# Altium schematic sheet HoverGate-V2-sch.SchDoc
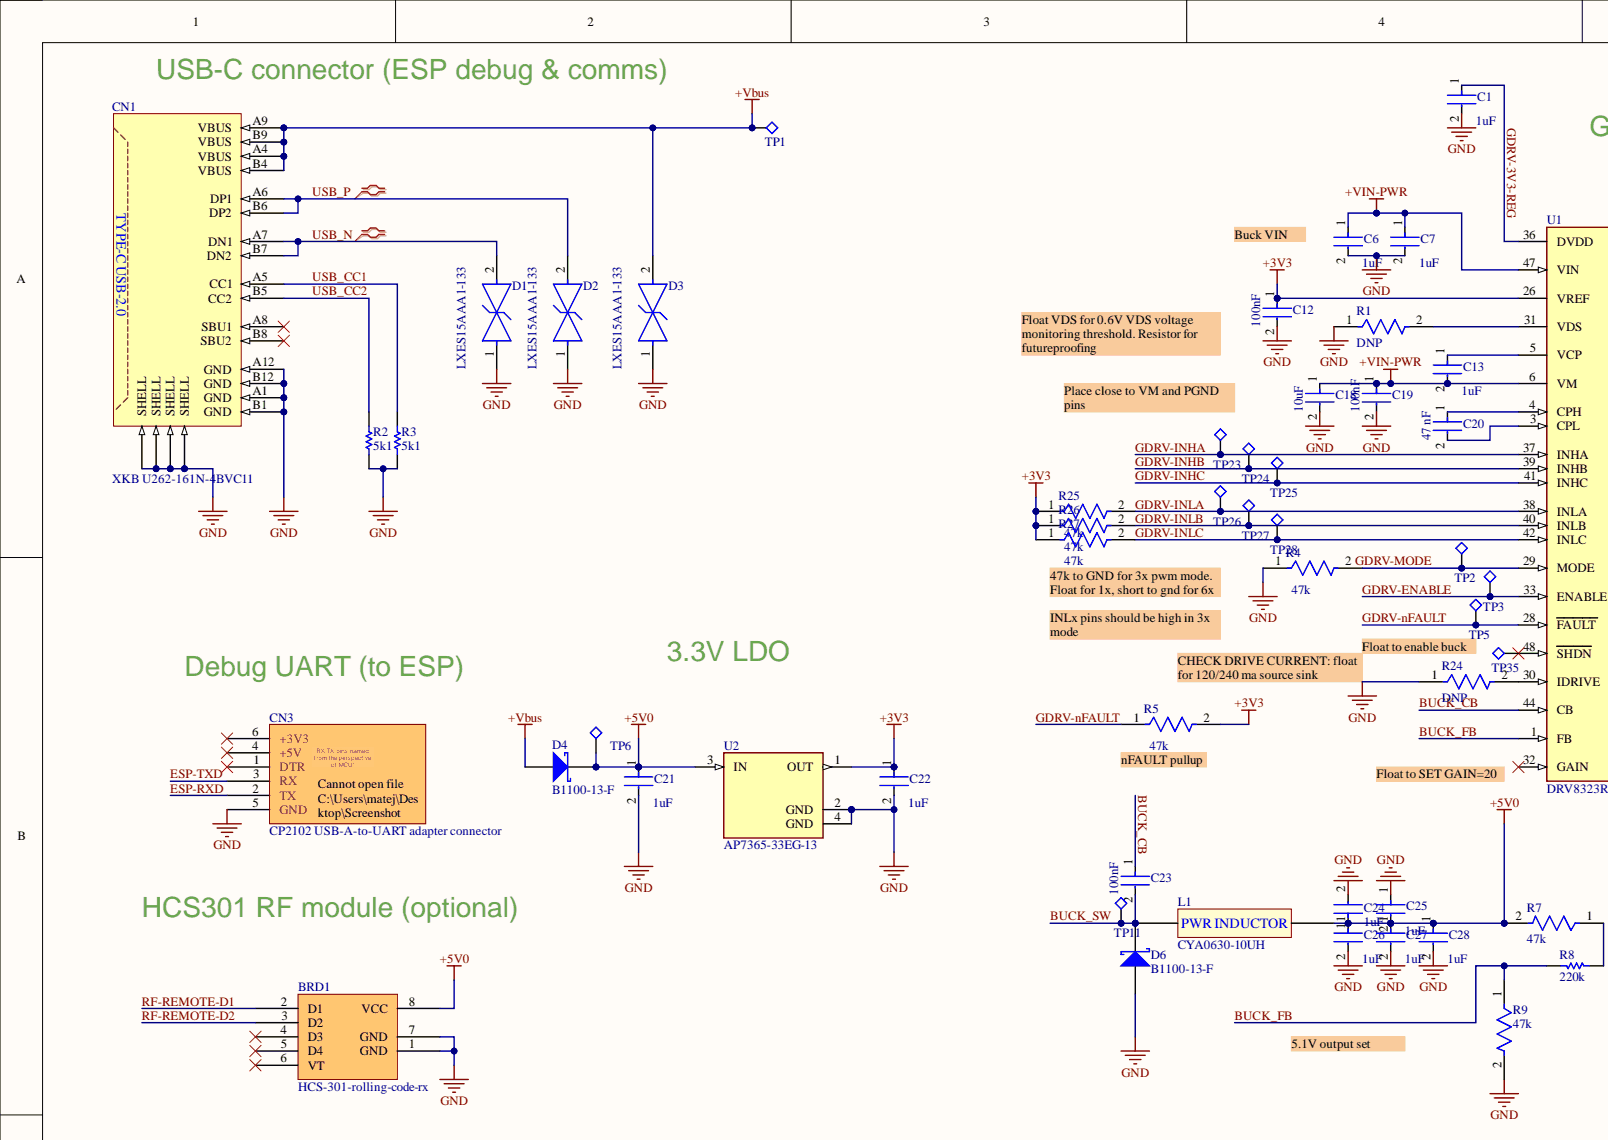
[diagram p0: HoverGate-V2-sch.SchDoc, top left]
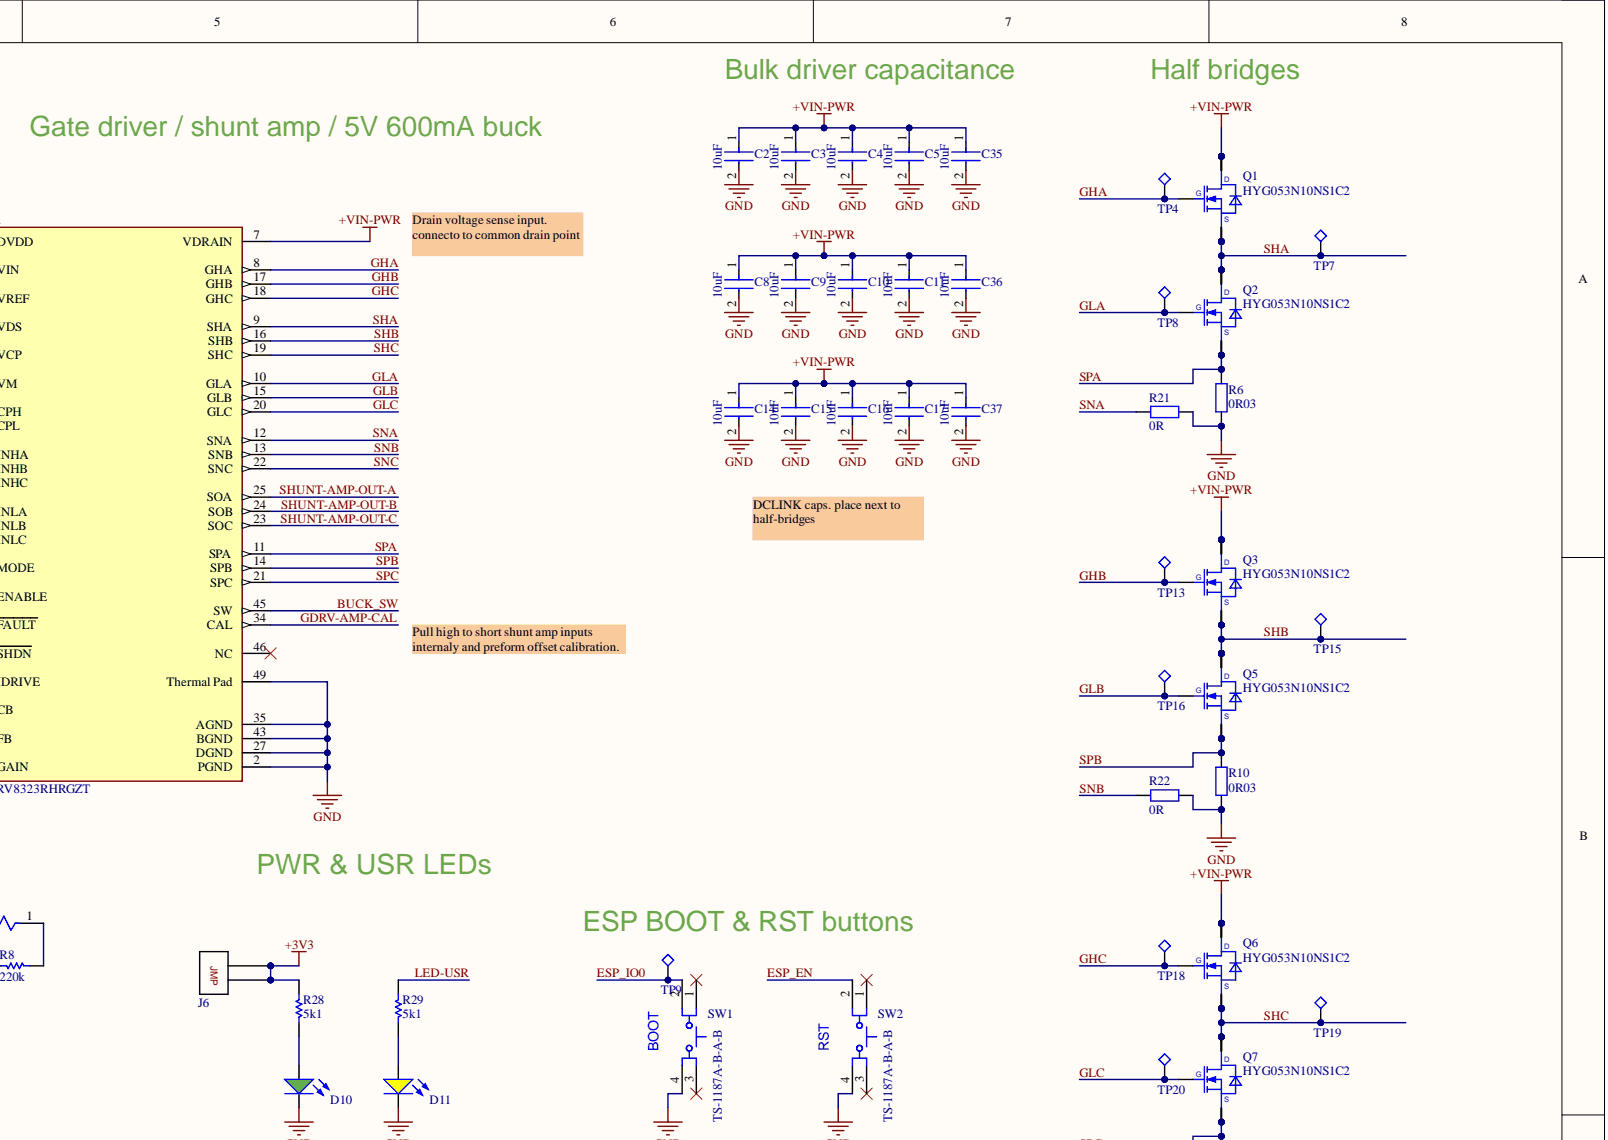
[diagram p1: HoverGate-V2-sch.SchDoc, top right]
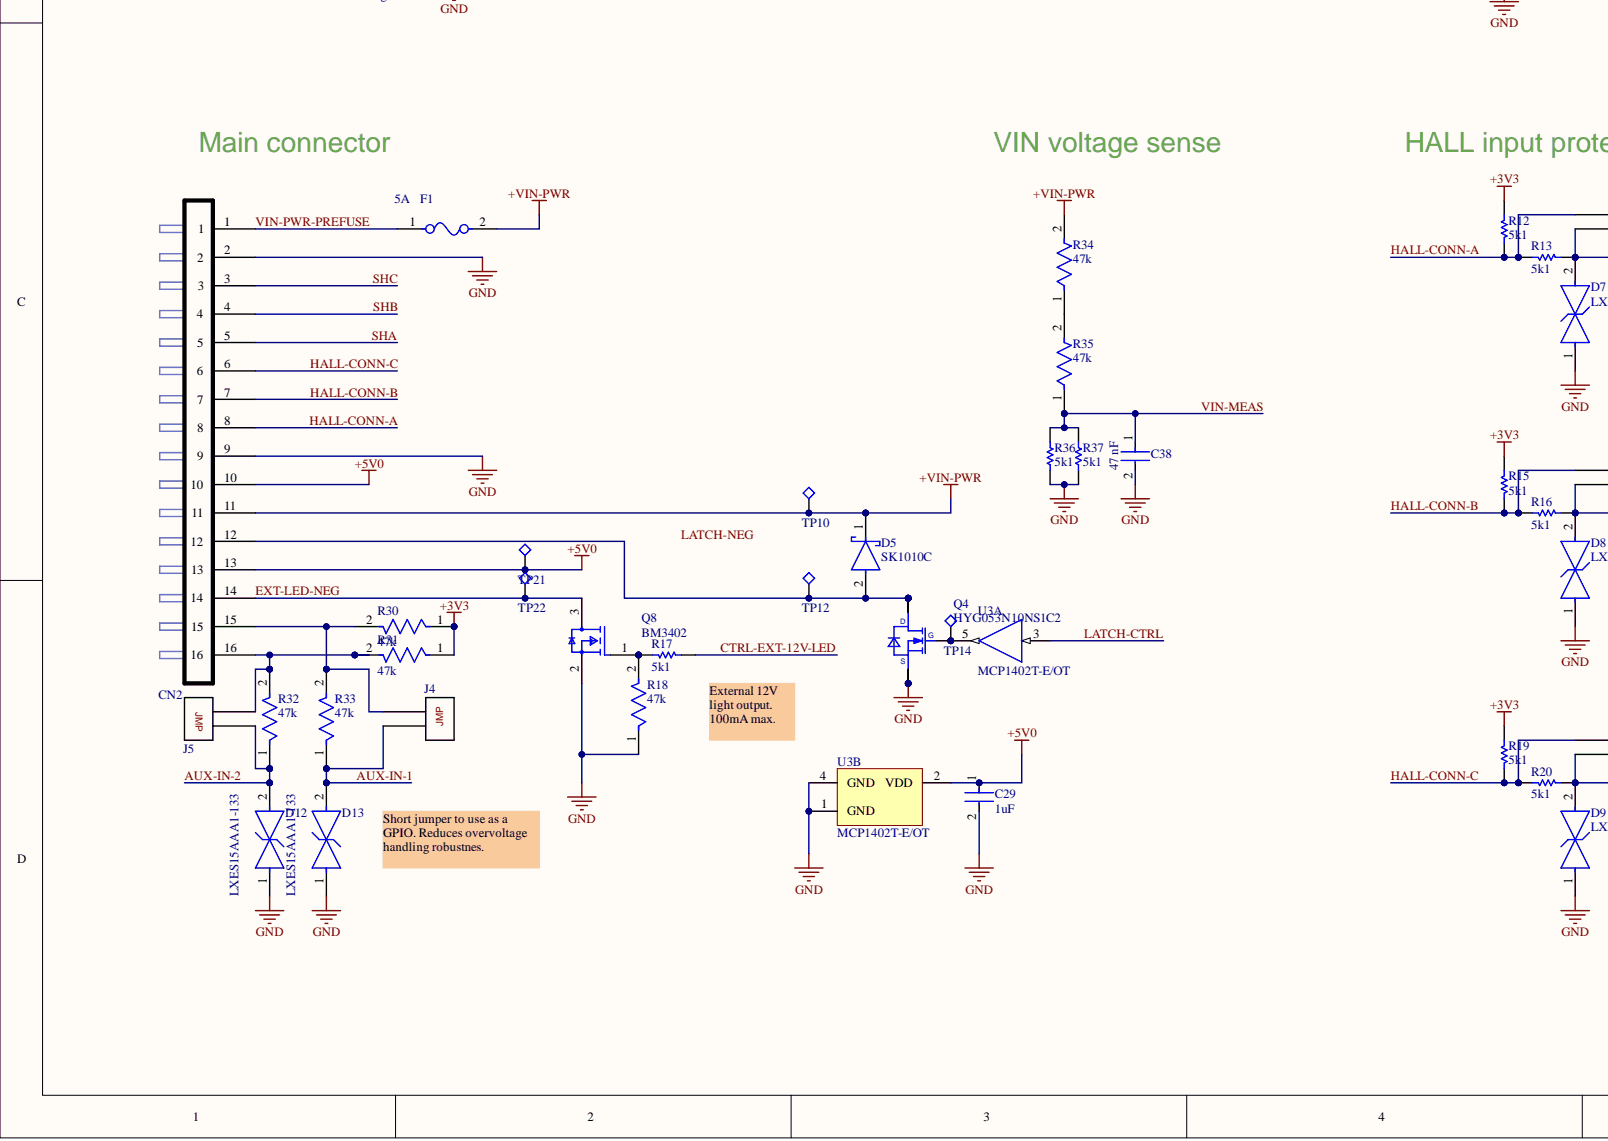
[diagram p2: HoverGate-V2-sch.SchDoc, bottom left]
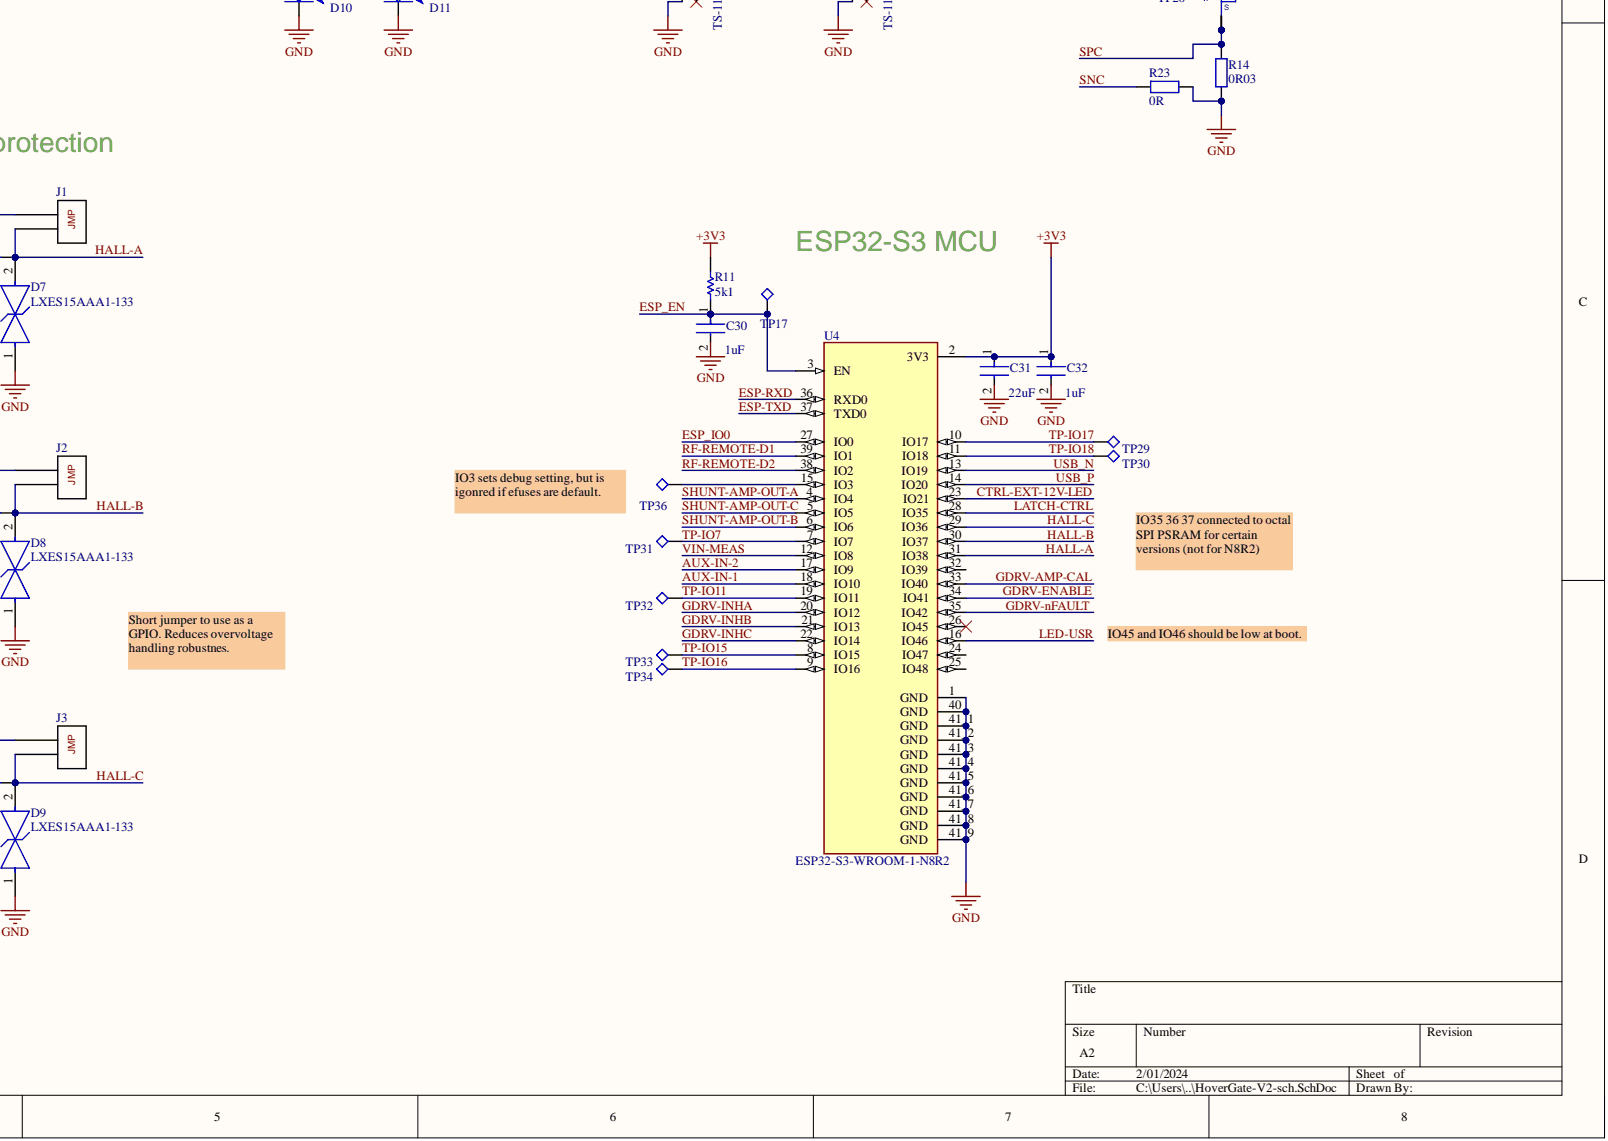
[diagram p3: HoverGate-V2-sch.SchDoc, bottom right]

## Parts
BRD1  HCS-301-rolling-code-rx  [HC301-module]
C1  value 1uF  Capacitance: 1uF  [FP-CL10B105KO8NNNC-IPC_B]  (hidden comment: CL10A105KB8NNNC)
C2  Capacitance: 10uF  [FP-GRM32E-0_2-0_3-MFG]  (hidden comment: GRM32ER71J106KA12L)
C3  Capacitance: 10uF  [FP-GRM32E-0_2-0_3-MFG]  (hidden comment: GRM32ER71J106KA12L)
C4  Capacitance: 10uF  [FP-GRM32E-0_2-0_3-MFG]  (hidden comment: GRM32ER71J106KA12L)
C5  Capacitance: 10uF  [FP-GRM32E-0_2-0_3-MFG]  (hidden comment: GRM32ER71J106KA12L)
C6  value 1uF  Capacitance: 1uF  [FP-CL10B105KO8NNNC-IPC_B]  (hidden comment: CL10A105KB8NNNC)
C7  value 1uF  Capacitance: 1uF  [FP-CL10B105KO8NNNC-IPC_B]  (hidden comment: CL10A105KB8NNNC)
C8  Capacitance: 10uF  [FP-GRM32E-0_2-0_3-MFG]  (hidden comment: GRM32ER71J106KA12L)
C9  Capacitance: 10uF  [FP-GRM32E-0_2-0_3-MFG]  (hidden comment: GRM32ER71J106KA12L)
C10  Capacitance: 10uF  [FP-GRM32E-0_2-0_3-MFG]  (hidden comment: GRM32ER71J106KA12L)
C11  Capacitance: 10uF  [FP-GRM32E-0_2-0_3-MFG]  (hidden comment: GRM32ER71J106KA12L)
C12  Capacitance: 100nF  [FP-CL10-IPC_A]  (hidden comment: CL10B104KC8NNNC)
C13  value 1uF  Capacitance: 1uF  [FP-CL10B105KO8NNNC-IPC_B]  (hidden comment: CL10A105KB8NNNC)
C14  Capacitance: 10uF  [FP-GRM32E-0_2-0_3-MFG]  (hidden comment: GRM32ER71J106KA12L)
C15  Capacitance: 10uF  [FP-GRM32E-0_2-0_3-MFG]  (hidden comment: GRM32ER71J106KA12L)
C16  Capacitance: 10uF  [FP-GRM32E-0_2-0_3-MFG]  (hidden comment: GRM32ER71J106KA12L)
C17  Capacitance: 10uF  [FP-GRM32E-0_2-0_3-MFG]  (hidden comment: GRM32ER71J106KA12L)
C18  Capacitance: 10uF  [FP-GRM32E-0_2-0_3-MFG]  (hidden comment: GRM32ER71J106KA12L)
C19  Capacitance: 100nF  [FP-CL10-IPC_A]  (hidden comment: CL10B104KC8NNNC)
C20  value 47 nF  Capacitance: 47 nF  [FP-AC0603-IPC_B]  (hidden comment: AC0603KRX7R0BB473)
C21  value 1uF  Capacitance: 1uF  [FP-CL10B105KO8NNNC-IPC_B]  (hidden comment: CL10A105KB8NNNC)
C22  value 1uF  Capacitance: 1uF  [FP-CL10B105KO8NNNC-IPC_B]  (hidden comment: CL10A105KB8NNNC)
C23  Capacitance: 100nF  [FP-CL10-IPC_A]  (hidden comment: CL10B104KC8NNNC)
C24  value 1uF  Capacitance: 1uF  [FP-CL10B105KO8NNNC-IPC_B]  (hidden comment: CL10A105KB8NNNC)
C25  value 1uF  Capacitance: 1uF  [FP-CL10B105KO8NNNC-IPC_B]  (hidden comment: CL10A105KB8NNNC)
C26  value 1uF  Capacitance: 1uF  [FP-CL10B105KO8NNNC-IPC_B]  (hidden comment: CL10A105KB8NNNC)
C27  value 1uF  Capacitance: 1uF  [FP-CL10B105KO8NNNC-IPC_B]  (hidden comment: CL10A105KB8NNNC)
C28  value 1uF  Capacitance: 1uF  [FP-CL10B105KO8NNNC-IPC_B]  (hidden comment: CL10A105KB8NNNC)
C29  value 1uF  Capacitance: 1uF  [FP-CL10B105KO8NNNC-IPC_B]  (hidden comment: CL10A105KB8NNNC)
C30  value 1uF  Capacitance: 1uF  [FP-CL10B105KO8NNNC-IPC_B]  (hidden comment: CL10A105KB8NNNC)
C31  Capacitance: 22uF  [FP-CL31-IPC_B]  (hidden comment: CL31A226KPHNNNE)
C32  value 1uF  Capacitance: 1uF  [FP-CL10B105KO8NNNC-IPC_B]  (hidden comment: CL10A105KB8NNNC)
C35  Capacitance: 10uF  [FP-GRM32E-0_2-0_3-MFG]  (hidden comment: GRM32ER71J106KA12L)
C36  Capacitance: 10uF  [FP-GRM32E-0_2-0_3-MFG]  (hidden comment: GRM32ER71J106KA12L)
C37  Capacitance: 10uF  [FP-GRM32E-0_2-0_3-MFG]  (hidden comment: GRM32ER71J106KA12L)
C38  value 47 nF  Capacitance: 47 nF  [FP-AC0603-IPC_B]  (hidden comment: AC0603KRX7R0BB473)
CN1  XKB U262-161N-4BVC11  [XKB U262-161N-4BVC11]
CN2  MAX MX15EDGVM-3.81-16P-GN01-Cu-A  [MAX MX15EDGVM-3.81-16P-GN01-Cu-A]  (value not drawn)
CN3  CP2102 USB-A-to-UART adapter connector  [CP2102 USB-A-to-UART adapter connector]
D1  LXES15AAA1-133  [FP-LXES15-IPC_A]
D2  LXES15AAA1-133  [FP-LXES15-IPC_A]
D3  LXES15AAA1-133  [FP-LXES15-IPC_A]
D4  B1100-13-F  [DIOD-SMA-2_V]
D5  SK1010C  [FP-SMC-MFG]
D6  B1100-13-F  [DIOD-SMA-2_V]
D7  LXES15AAA1-133  [FP-LXES15-IPC_A]
D8  LXES15AAA1-133  [FP-LXES15-IPC_A]
D9  LXES15AAA1-133  [FP-LXES15-IPC_A]
D10  KT-0805G  [LED_0805]  (value not drawn)
D11  KT-0805Y  [LED_0805]  (value not drawn)
D12  LXES15AAA1-133  [FP-LXES15-IPC_A]
D13  LXES15AAA1-133  [FP-LXES15-IPC_A]
F1  Current Rating: 5A  [FP-0469-IPC_B]  (hidden comment: 0458005.DR)
J1  SolderJumperV2  [AislerRule_SolderJumper_1mm]  (value not drawn)
J2  SolderJumperV2  [AislerRule_SolderJumper_1mm]  (value not drawn)
J3  SolderJumperV2  [AislerRule_SolderJumper_1mm]  (value not drawn)
J4  SolderJumperV2  [AislerRule_SolderJumper_1mm]  (value not drawn)
J5  SolderJumperV2  [AislerRule_SolderJumper_1mm]  (value not drawn)
J6  SolderJumperV2  [AislerRule_SolderJumper_1mm]  (value not drawn)
L1  CYA0630-10UH  [CYA0630-10UH]
Q1  HYG053N10NS1C2  [PDFN5*6-8L]
Q2  HYG053N10NS1C2  [PDFN5*6-8L]
Q3  HYG053N10NS1C2  [PDFN5*6-8L]
Q4  HYG053N10NS1C2  [PDFN5*6-8L]
Q5  HYG053N10NS1C2  [PDFN5*6-8L]
Q6  HYG053N10NS1C2  [PDFN5*6-8L]
Q7  HYG053N10NS1C2  [PDFN5*6-8L]
Q8  BM3402  [FP-SOT23-IPC_B]
R1  DNP  [FP-RC0603FR-0747KL-MFG]
R2  value 5k1  [RESC1608X55X30NL15T15]  (hidden comment: 0603WAF5101T5E)
R3  value 5k1  [RESC1608X55X30NL15T15]  (hidden comment: 0603WAF5101T5E)
R4  Resistance: 47k  [FP-RC0603FR-0747KL-MFG]  (hidden comment: RC0603FR-0747KL)
R5  Resistance: 47k  [FP-RC0603FR-0747KL-MFG]  (hidden comment: RC0603FR-0747KL)
R6  value 0R03  [FPR_CRF2512_R0]  (hidden comment: LR2512D-3W-3mR-1%)
R7  Resistance: 47k  [FP-RC0603FR-0747KL-MFG]  (hidden comment: RC0603FR-0747KL)
R8  value 220k  [RESC1608X55X30NL15T15]  (hidden comment: 0603WAF2203T5E)
R9  Resistance: 47k  [FP-RC0603FR-0747KL-MFG]  (hidden comment: RC0603FR-0747KL)
R10  value 0R03  [FPR_CRF2512_R0]  (hidden comment: LR2512D-3W-3mR-1%)
R11  value 5k1  [RESC1608X55X30NL15T15]  (hidden comment: 0603WAF5101T5E)
R12  value 5k1  [RESC1608X55X30NL15T15]  (hidden comment: 0603WAF5101T5E)
R13  value 5k1  [RESC1608X55X30NL15T15]  (hidden comment: 0603WAF5101T5E)
R14  value 0R03  [FPR_CRF2512_R0]  (hidden comment: LR2512D-3W-3mR-1%)
R15  value 5k1  [RESC1608X55X30NL15T15]  (hidden comment: 0603WAF5101T5E)
R16  value 5k1  [RESC1608X55X30NL15T15]  (hidden comment: 0603WAF5101T5E)
R17  value 5k1  [RESC1608X55X30NL15T15]  (hidden comment: 0603WAF5101T5E)
R18  Resistance: 47k  [FP-RC0603FR-0747KL-MFG]  (hidden comment: RC0603FR-0747KL)
R19  value 5k1  [RESC1608X55X30NL15T15]  (hidden comment: 0603WAF5101T5E)
R20  value 5k1  [RESC1608X55X30NL15T15]  (hidden comment: 0603WAF5101T5E)
R21  0R  [FPR_0603_R_R0]
R22  0R  [FPR_0603_R_R0]
R23  0R  [FPR_0603_R_R0]
R24  DNP  [FP-RC0603FR-0747KL-MFG]
R25  RC0603FR-0747KL  [FP-RC0603FR-0747KL-MFG]  (value not drawn)
R26  Resistance: 47k  [FP-RC0603FR-0747KL-MFG]  (hidden comment: RC0603FR-0747KL)
R27  Resistance: 47k  [FP-RC0603FR-0747KL-MFG]  (hidden comment: RC0603FR-0747KL)
R28  value 5k1  [RESC1608X55X30NL15T15]  (hidden comment: 0603WAF5101T5E)
R29  value 5k1  [RESC1608X55X30NL15T15]  (hidden comment: 0603WAF5101T5E)
R30  Resistance: 47k  [FP-RC0603FR-0747KL-MFG]  (hidden comment: RC0603FR-0747KL)
R31  Resistance: 47k  [FP-RC0603FR-0747KL-MFG]  (hidden comment: RC0603FR-0747KL)
R32  Resistance: 47k  [FP-RC0603FR-0747KL-MFG]  (hidden comment: RC0603FR-0747KL)
R33  Resistance: 47k  [FP-RC0603FR-0747KL-MFG]  (hidden comment: RC0603FR-0747KL)
R34  Resistance: 47k  [FP-RC0603FR-0747KL-MFG]  (hidden comment: RC0603FR-0747KL)
R35  Resistance: 47k  [FP-RC0603FR-0747KL-MFG]  (hidden comment: RC0603FR-0747KL)
R36  value 5k1  [RESC1608X55X30NL15T15]  (hidden comment: 0603WAF5101T5E)
R37  value 5k1  [RESC1608X55X30NL15T15]  (hidden comment: 0603WAF5101T5E)
SW1  TS-1187A-B-A-B  [ESWI-TL3342F160QG_V]
SW2  TS-1187A-B-A-B  [ESWI-TL3342F160QG_V]
TP1  test point 1.5mm  [TP1_FULL]  (value not drawn)
TP2  test point 1.5mm  [TP1_FULL]  (value not drawn)
TP3  test point 1.5mm  [TP1_FULL]  (value not drawn)
TP4  test point 1.5mm  [TP1_FULL]  (value not drawn)
TP5  test point 1.5mm  [TP1_FULL]  (value not drawn)
TP6  test point 1.5mm  [TP1_FULL]  (value not drawn)
TP7  test point 1.5mm  [TP1_FULL]  (value not drawn)
TP8  test point 1.5mm  [TP1_FULL]  (value not drawn)
TP9  test point 1.5mm  [TP1_FULL]  (value not drawn)
TP10  test point 1.5mm  [TP1_FULL]  (value not drawn)
TP11  test point 1.5mm  [TP1_FULL]  (value not drawn)
TP12  test point 1.5mm  [TP1_FULL]  (value not drawn)
TP13  test point 1.5mm  [TP1_FULL]  (value not drawn)
TP14  test point 1.5mm  [TP1_FULL]  (value not drawn)
TP15  test point 1.5mm  [TP1_FULL]  (value not drawn)
TP16  test point 1.5mm  [TP1_FULL]  (value not drawn)
TP17  test point 1.5mm  [TP1_FULL]  (value not drawn)
TP18  test point 1.5mm  [TP1_FULL]  (value not drawn)
TP19  test point 1.5mm  [TP1_FULL]  (value not drawn)
TP20  test point 1.5mm  [TP1_FULL]  (value not drawn)
TP21  test point 1.5mm  [TP1_FULL]  (value not drawn)
TP22  test point 1.5mm  [TP1_FULL]  (value not drawn)
TP23  test point 1.5mm  [TP1_FULL]  (value not drawn)
TP24  test point 1.5mm  [TP1_FULL]  (value not drawn)
TP25  test point 1.5mm  [TP1_FULL]  (value not drawn)
TP26  test point 1.5mm  [TP1_FULL]  (value not drawn)
TP27  test point 1.5mm  [TP1_FULL]  (value not drawn)
TP28  test point 1.5mm  [TP1_FULL]  (value not drawn)
TP29  test point 1.5mm  [TP1_FULL]  (value not drawn)
TP30  test point 1.5mm  [TP1_FULL]  (value not drawn)
TP31  test point 1.5mm  [TP1_FULL]  (value not drawn)
TP32  test point 1.5mm  [TP1_FULL]  (value not drawn)
TP33  test point 1.5mm  [TP1_FULL]  (value not drawn)
TP34  test point 1.5mm  [TP1_FULL]  (value not drawn)
TP35  test point 1.5mm  [TP1_FULL]  (value not drawn)
TP36  test point 1.5mm  [TP1_FULL]  (value not drawn)
U1  DRV8323RHRGZT  [FP-RGZ0048A-IPC_A]
U2  AP7365-33EG-13  [FP-SOT223-IPC_C]
U3  MCP1402T-E/OT  [SOT-23-OT5_L]  (parts A,B)
U4  ESP32-S3-WROOM-1-N8R2  [XCVR_ESP32-S3-WROOM-1-N8R2]

## Nets
+3V3: C12.1 C22.1 C31.1 C32.1 J6.1 J6.2 R5.2 R11.2 R12.2 R15.2 R19.2 R25.1 R26.1 R27.1 R28.2 R30.1 R31.1 U1.26(VREF) U2.1(OUT) U4.2(3V3)
+5V0: BRD1.8(VCC) C21.1 C24.1 C25.2 C26.1 C27.1 C28.1 C29.1 CN2.10 CN2.13 D4.2 L1.2 R7.2 TP6.1 TP21.1 U2.3(IN) U3.2(VDD)
+Vbus: CN1.A4(VBUS) CN1.A9(VBUS) CN1.B4(VBUS) CN1.B9(VBUS) D3.2 D4.1 TP1.1
+VIN-PWR: C2.1 C3.1 C4.1 C5.1 C6.1 C7.1 C8.1 C9.1 C10.1 C11.1 C13.2 C14.1 C15.1 C16.1 C17.1 C18.1 C19.1 C35.1 C36.1 C37.1 CN2.11 D5.1 F1.2 Q1.5 Q1.6 Q1.7 Q1.8 Q1.9 Q3.5 Q3.6 Q3.7 Q3.8 Q3.9 Q6.5 Q6.6 Q6.7 Q6.8 Q6.9 R34.2 TP10.1 U1.6(VM) U1.7(VDRAIN) U1.47(VIN)
GND: BRD1.1(GND) BRD1.7(GND) C1.2 C2.2 C3.2 C4.2 C5.2 C6.2 C7.2 C8.2 C9.2 C10.2 C11.2 C12.2 C14.2 C15.2 C16.2 C17.2 C18.2 C19.2 C21.2 C22.2 C24.2 C25.1 C26.2 C27.2 C28.2 C29.2 C30.2 C31.2 C32.2 C35.2 C36.2 C37.2 C38.2 CN1.A1(GND) CN1.A12(GND) CN1.B1(GND) CN1.B12(GND) CN1.SHELL1(SHELL) CN1.SHELL2(SHELL) CN1.SHELL3(SHELL) CN1.SHELL4(SHELL) CN2.2 CN2.9 CN3.5(GND) D1.1 D2.1 D3.1 D6.1 D7.1 D8.1 D9.1 D10.1 D11.1 D12.1 D13.1 Q4.1 Q4.2 Q4.3 Q8.2 R1.1 R2.1 R3.1 R4.1 R6.1 R9.2 R10.1 R14.1 R18.1 R21.1 R22.1 R23.1 R24.1 R36.2 R37.2 SW1.4 SW2.4 U1.2(PGND) U1.27(DGND) U1.35(AGND) U1.43(BGND) U1.49(Thermal_Pad) U2.2(GND) U2.4(GND) U3.1(GND) U3.4(GND) U4.1(GND) U4.40(GND) U4.41_1(GND) U4.41_2(GND) U4.41_3(GND) U4.41_4(GND) U4.41_5(GND) U4.41_6(GND) U4.41_7(GND) U4.41_8(GND) U4.41_9(GND)
AUX-IN-1: D13.2 J4.2 R33.1 U4.18(IO10)
AUX-IN-2: D12.2 J5.1 R32.1 U4.17(IO9)
BUCK_CB: C23.1 U1.44(CB)
BUCK_FB: R8.2 R9.1 U1.1(FB)
BUCK_SW: C23.2 D6.2 L1.1 TP11.1 U1.45(SW)
CTRL-EXT-12V-LED: R17.2 U4.23(IO21)
ESP-RXD: CN3.2(TX) U4.36(RXD0)
ESP-TXD: CN3.3(RX) U4.37(TXD0)
ESP_EN: C30.1 R11.1 SW2.2 TP17.1 U4.3(EN)
ESP_IO0: SW1.2 TP9.1 U4.27(IO0)
EXT-LED-NEG: CN2.14 Q8.3 TP22.1
GDRV-3V3-REG: C1.1 U1.36(DVDD)
GDRV-AMP-CAL: U1.34(CAL) U4.33(IO40)
GDRV-ENABLE: TP3.1 U1.33(ENABLE) U4.34(IO41)
GDRV-INHA: TP23.1 U1.37(INHA) U4.20(IO12)
GDRV-INHB: TP24.1 U1.39(INHB) U4.21(IO13)
GDRV-INHC: TP25.1 U1.41(INHC) U4.22(IO14)
GDRV-INLA: R25.2 TP26.1 U1.38(INLA)
GDRV-INLB: R26.2 TP27.1 U1.40(INLB)
GDRV-INLC: R27.2 TP28.1 U1.42(INLC)
GDRV-MODE: R4.2 TP2.1 U1.29(MODE)
GDRV-nFAULT: R5.1 TP5.1 U1.28(FAULT) U4.35(IO42)
GHA: Q1.4 TP4.1 U1.8(GHA)
GHB: Q3.4 TP13.1 U1.17(GHB)
GHC: Q6.4 TP18.1 U1.18(GHC)
GLA: Q2.4 TP8.1 U1.10(GLA)
GLB: Q5.4 TP16.1 U1.15(GLB)
GLC: Q7.4 TP20.1 U1.20(GLC)
HALL-A: D7.2 J1.2 R13.1 U4.31(IO38)
HALL-B: D8.2 J2.2 R16.1 U4.30(IO37)
HALL-C: D9.2 J3.2 R20.1 U4.29(IO36)
HALL-CONN-A: CN2.8 J1.1 R12.1 R13.2
HALL-CONN-B: CN2.7 J2.1 R15.1 R16.2
HALL-CONN-C: CN2.6 J3.1 R19.1 R20.2
LATCH-CTRL: U3.3 U4.28(IO35)
LED-USR: R29.2 U4.16(IO46)
RF-REMOTE-D1: BRD1.2(D1) U4.39(IO1)
RF-REMOTE-D2: BRD1.3(D2) U4.38(IO2)
SHA: CN2.5 Q1.1 Q1.2 Q1.3 Q2.5 Q2.6 Q2.7 Q2.8 Q2.9 TP7.1 U1.9(SHA)
SHB: CN2.4 Q3.1 Q3.2 Q3.3 Q5.5 Q5.6 Q5.7 Q5.8 Q5.9 TP15.1 U1.16(SHB)
SHC: CN2.3 Q6.1 Q6.2 Q6.3 Q7.5 Q7.6 Q7.7 Q7.8 Q7.9 TP19.1 U1.19(SHC)
SHUNT-AMP-OUT-A: U1.25(SOA) U4.4(IO4)
SHUNT-AMP-OUT-B: U1.24(SOB) U4.6(IO6)
SHUNT-AMP-OUT-C: U1.23(SOC) U4.5(IO5)
SNA: R21.2 U1.12(SNA)
SNB: R22.2 U1.13(SNB)
SNC: R23.2 U1.22(SNC)
SPA: Q2.1 Q2.2 Q2.3 R6.2 U1.11(SPA)
SPB: Q5.1 Q5.2 Q5.3 R10.2 U1.14(SPB)
SPC: Q7.1 Q7.2 Q7.3 R14.2 U1.21(SPC)
TP-IO7: TP31.1 U4.7(IO7)
TP-IO11: TP32.1 U4.19(IO11)
TP-IO15: TP33.1 U4.8(IO15)
TP-IO16: TP34.1 U4.9(IO16)
TP-IO17: TP29.1 U4.10(IO17)
TP-IO18: TP30.1 U4.11(IO18)
USB_CC1: CN1.A5(CC1) R3.2
USB_CC2: CN1.B5(CC2) R2.2
USB_N: CN1.A7(DN1) CN1.B7(DN2) D1.2 U4.13(IO19)
USB_P: CN1.A6(DP1) CN1.B6(DP2) D2.2 U4.14(IO20)
VIN-MEAS: C38.1 R35.1 R36.1 R37.1 U4.12(IO8)
VIN-PWR-PREFUSE: CN2.1 F1.1
NetC13_1: C13.1 U1.5(VCP)
NetC20_1: C20.1 U1.4(CPH)
NetC20_2: C20.2 U1.3(CPL)
NetCN2_12: CN2.12 D5.2 Q4.5 Q4.6 Q4.7 Q4.8 Q4.9 TP12.1
NetCN2_15: CN2.15 J4.1 R30.2 R33.2
NetCN2_16: CN2.16 J5.2 R31.2 R32.2
NetD10_2: D10.2 R28.1
NetD11_2: D11.2 R29.1
NetQ4_4: Q4.4 TP14.1 U3.5
NetQ8_1: Q8.1 R17.1 R18.2
NetR1_2: R1.2 U1.31(VDS)
NetR7_1: R7.1 R8.1
NetR24_2: R24.2 U1.30(IDRIVE)
NetR34_1: R34.1 R35.2
NetTP35_1: TP35.1 U1.48(SHDN)
NetTP36_1: TP36.1 U4.15(IO3)
LATCH-NEG:

Not connected: BRD1 4(D3) 5(D4) 6(VT); CN1 A8(SBU1) B8(SBU2); CN3 1(DTR) 4(+5V) 6(+3V3); SW1 1 3; SW2 1 3; U1 32(GAIN) 46(NC); U4 24(IO47) 25(IO48) 26(IO45) 32(IO39)

## Library data of the parts
C1 C6 C7 C13 C21 C22 C24-C30 C32 = CMP-2000-06093-2: CAP CER 1UF 50V X5R 0603; Manufacturer: Samsung Electro-Mechanics America, Inc; Manufacturer Part Number: CL10B105KO8NNNC; Material: Ceramic; Temperature Coefficient: 15%; Tolerance: 10%; Automotive: No; Category: Cap; Component Kind: Standard; ComponentLink2Description: Datasheet; ComponentLink2URL: http://www.samsungsem.com/global/front/downloadcms.do?path=%2F%2Fglobal%2F%2Fsupport%2F%2Fproduct-search%2F%2Fmlcc%2F%2F__icsFiles%2F%2Fafieldfile%2F%2F2019%2F%2F06%2F%2F18&fileName=CL10B105KO8NNNC-19.pdf; Device Class L1: Passive Components; Device Class L2: Capacitors; Device Class L3: Ceramic Capacitors; Digikey Description: CAP CER 1UF 16V X7R 0603; Height: 0.9mm; Ipc Land Pattern Name: CAPC16080X80; LCSC: C15849; Lead Free: Yes; Library Name: Altium Content Vault; Octopart: https://octopart.com/cl10b105ko8nnnc-samsung-19018973; Package: CL10B105KO8NNNC; RoHS: TRUE; Temperature: -55°C to +125°C; Temperature Characteristic: X5R; Temperature Range High: +125°C; Temperature Range Low: -55°C; Voltage Rating: 50V
C2-C5 C8-C11 C14-C18 C35-C37 = CMP-2000-07458-2: CAP CER 10UF 63V X7R 1210; Dielectric Material: Ceramic; Tolerance: 10%; Case Code (Imperial): 1210; Case Code (Metric): 3225; Case/Package: 1210; Component Kind: Standard; Depth: 2.5mm; Dielectric: X7R; Height: 2.5mm; LCSC: C437568; Lead Free: Lead Free; Length: 3.2mm; Library Name: Altium Content Vault; Material: Ceramic; Max Operating Temperature: 125°C; Min Operating Temperature: -55°C; Mount: Surface Mount; Package Quantity: 1000; Packaging: Tape and Reel; REACH SVHC: No SVHC; RoHS Compliant: Yes; Termination: SMD/SMT; Thickness: 0.106inch; Voltage: 63V; Voltage Rating: 63V; Voltage Rating (DC): 63V; Weight: 0.00388oz; Width: 2.5mm
C12 C19 C23 = CMP-13271-004036-1: Cap Ceramic 100nF 100V X7R ±10% Pad SMD 0603 +125°C T/R; Case Code (Imperial): 0603; Case Code (Metric): 1608; Case/Package: 0603; Component Kind: Standard; Length: 0.063inch; Library Name: Altium Content Vault; Max Operating Temperature: 125°C; Min Operating Temperature: -55°C; Mount: Surface Mount; Packaging: Cut Tape; Thickness: 0.035inch; Tolerance: 10%; Voltage Rating: 100V; Width: 0.031inch
C20 C38 = CMP-03422-001184-1: CAP CER 0.047UF 100V X7R 0603; Manufacturer: Yageo; Automotive Grade: Grade 1; Material: Ceramic; Temperature Coefficient: 15%; Tolerance: 0.1; Automotive: Yes; Category: Cap; Component Kind: Standard; ComponentLink2Description: Datasheet; ComponentLink2URL: http://www.yageo.com/documents/recent/UPY-AC_NP0X7R_16V-to-630V_12.pdf; Device Class L1: Passive Components; Device Class L2: Capacitors; Device Class L3: Ceramic Capacitors; Digikey Description: CAP CER 0.047UF 100V X7R 0603; Footprint Url: http://www.yageo.com/exep/pages/download/literatures/UPY-C_GEN_24.pdf; Height: 0.9mm; Ipc Land Pattern Name: CAPC16080X80; LCSC: C469624; Lead Free: Yes; Library Name: Altium Content Vault; Manufacturer Part Number: AC0603KRX7R0BB473; Mouser Description: AC Series 0603 47 nF 100 V ±10% X7R Auto Multilayer Ceramic Chip Capacitor; Octopart: https://octopart.com/ac0603krx7r0bb473-yageo-87214085; Package: AC0603; RoHS: TRUE; Temperature: -55°C to +125°C; Temperature Characteristic: X7R; Temperature Range High: +125°C; Temperature Range Low: -55°C; Voltage Rating: 100 V
C31 = CMP-13271-002604-1: Cap Ceramic 22uF 10V X5R ±10% SMD 1206; Case Code (Imperial): 1206; Case Code (Metric): 3216; Case/Package: 1206; Component Kind: Standard; Depth: 1.6mm; Dielectric: X5R; Height: 1.6mm; LCSC: C5672; Lead Free: Lead Free; Length: 3.2mm; Library Name: Altium Content Vault; Max Operating Temperature: 85°C; Min Operating Temperature: -55°C; Mount: Surface Mount; Package Quantity: 1; Packaging: Tape and Reel; Radiation Hardening: No; Thickness: 0.071inch; Tolerance: 10%; Voltage Rating: 10V; Voltage Rating (DC): 10V; Width: 0.063inch
CN1 = XKB U262-161N-4BVC11: USB Type-C connector, USB-2.0, 16 pins; Component Kind: Standard; LCSC: C319148; Library Name: planinsekLib_sch.SchLib
CN2 = MAX MX15EDGVM-3.81-16P-GN01-Cu-A: Board connector for 16 pin pluggable screw terminal; Component Kind: Standard; LCSC: C5188240; Library Name: planinsekLib_sch.SchLib
D1-D3 D7-D9 D12 D13 = CMP-06195-000011-1: TVS DIODE 15V 1005; Number of Bidirectional Channels: 1; Power Line Protection: No; Case Code (Imperial): 0402; Case Code (Metric): 1005; Case/Package: 0402; Component Kind: Standard; LCSC: C191267; Library Name: Altium Content Vault; Max Operating Temperature: 85°C; Min Operating Temperature: -40°C; Mount: Surface Mount; Packaging: Tape and Reel; Resistor Type: Zener; Reverse Standoff Voltage: 15V
D4 D6 = CMP-2000-05882-1: Schottky Barrier Rectifier, 100 V, 1 A, -65 to 150 degC, 2-Pin SMA, RoHS, Tape and Reel; Brand: DiodesIncorporated; Configuration: Single; If - Forward Current: 1A; Ifsm - Forward Surge Current: 30A; Ir - Reverse Current: 500uA; Unit Weight: 0.002258oz; Vf - Forward Voltage: 0.79V; Vrrm - Repetitive Reverse Voltage: 100V; Component Kind: Standard; Datasheet URL: http://www.diodes.com/_files/datasheets/ds30018.pdf; Datasheet Version: 01/2016; Factory Pack Quantity: 5000; Height: 2.1mm; LCSC: C110106; Length: 4.6mm; Library Name: Altium Content Vault; Manufacturer: DiodesIncorporated; Manufacturer URL: http://www.diodes.com/; Maximum Operating Temperature: +150C; Minimum Operating Temperature: -65C; Mounting Style: SMD/SMT; Mounting Technology: SurfaceMount; Operating Temperature Range: -65Cto+150C; Package / Case: SMA; Package Description: 2-Pin Surface Mounted Assembly, Body 4.3 x 2.605 mm, Height 2.4 mm; Package Reference: SMA-2; Packaging: Reel; Product: SchottkyDiodes; Product Category: SchottkyDiodes&Rectifiers; RoHS: True; Series: B1100; Technology: Si; Termination Style: SMD/SMT; Type: SchottkyBarrierRectifier; Width: 2.92mm
D5 = CMP-2000-05097-3: DIODE SCHOTTKY 100V 10A SMC; Manufacturer: Diodes Inc.; Manufacturer Part Number: B540C-13-F; Automotive Grade: NA; Capacitance: 300pF; Forward Current: 5A; Max Junction Temp: +150°C; Max Repetitive Reverse Voltage: 40V; Max Surge Forward Current: 100A; Peak Reverse Current: 0.5mA; Standoff Height: 0.1mm; Value: 300pF; Automotive: No; Category: Diode; Component Kind: Standard; ComponentLink2Description: Datasheet; ComponentLink2URL: https://www.diodes.com/assets/Datasheets/ds13012.pdf; Device Class L1: Discrete Semiconductors; Device Class L2: Diodes; Device Class L3: Schottky Diodes; Digikey Description: DIODE SCHOTTKY 40V 5A SMC; Footprint Url: https://www.diodes.com/assets/Package-Files/SMC.pdf; Height: 2.5mm; LCSC: C3018527; Lead Free: Yes; Library Name: Altium Content Vault; Mouser Description: Diode: Schottky rectifying; SMD; 40V; 5A; SMC; Package: reel, tape; Octopart: https://octopart.com/b540c-13-f-diodes+inc.-325873; Package: SMC; Reverse Voltage: 40V; RoHS: TRUE; Temperature: -55°C to +150°C; Temperature Range High: +150°C; Temperature Range Low: -55°C
D10 = LED Green: KT-0805G LED Green; Component Kind: Standard; LCSC: C2297; Library Name: DAQ.SchLib
D11 = LED Blue: LED Yellow KT-0805Y; Component Kind: Standard; LCSC: C2296; Library Name: DAQ.SchLib
F1 = CMP-08605-000100-1: FUSE BOARD MOUNT 5A 75VDC 1206; Approvals: UL; Blow Characteristic: TIME DELAY; Body Material: Ceramic; DC Cold Resistance: 35mR; Fuse Type: Board Mount (Cartridge Style Excluded); Power Dissipation: 1.08W; Voltage Rating: 32V; Case Code (Imperial): 1206; Case Code (Metric): 3216; Case/Package: 1206; Component Kind: Standard; Depth: 1.63mm; Fuse Current: 5A; Height: 0.9mm; Housing Material: Ceramic; LCSC: C187561; Lead Free: Lead Free; Length: 3.27mm; Library Name: Altium Content Vault; Max Operating Temperature: 150°C; Min Operating Temperature: -55°C; Mount: Surface Mount; Number of Pins: 2; Package Quantity: 3000; Packaging: Tape and Reel; Radiation Hardening: No; Resistance: 35mR; Resistor Type: Ceramic; RoHS Compliant: Yes; Series: 469; Size: 0.9mm; Terminal Material: Nickel; Termination: SMD/SMT; Voltage Rating (DC): 32V; Width: 1.63mm; Working Voltage: 32V
L1 = PowerInductor: SMD Power inductio, 10uH 4A; Component Kind: Standard; ComponentLink1Description: Datasheet; LCSC: C5189958; Library Name: planinsekLib_sch.SchLib
Q1-Q7 = HYG053N10NS1C2: 100V 95A 4.6mOhm N-channel mosfet; Component Kind: Standard; Library Name: planinsekLib_sch.SchLib
Q8 = CMP-83955-000006-1: BORN BM3402 MOSFET N-CH 30V 5.1A SOT23; Component Kind: Standard; LCSC: C431498; Library Name: Altium Content Vault
R1 R4 R5 R7 R9 R18 R24-R27 R30-R35 = CMP-1659-00055-2: RES SMD 47K OHM 1% 1/10W 0603; Max Power Dissipation: 100mW; Temperature Coefficient: 100ppm/°C; Voltage Rating: 50V; Case Code (Imperial): 0603; Case Code (Metric): 1608; Case/Package: 0603; Component Kind: Standard; Construction: Rectangular; Depth: 0.8mm; Features: Moisture Resistant; Height: 0.022inch; LCSC: C105579; Lead Free: Lead Free; Length: 0.063inch; Library Name: Altium Content Vault; Max Operating Temperature: 155°C; Military Standard: Not; Min Operating Temperature: -55°C; Number of Pins: 2; Number of Terminations: 2; Package Quantity: 1; Packaging: Tape and Reel; Power Rating: 100mW; Resistance Tolerance: 1%; Resistor Type: Thick Film; RoHS Compliant: Yes; Series: RC; Size Code: 0603; Technology: THICK FILM; Termination: SMD/SMT; Tolerance: 1%; Voltage Rating (DC): 50V; Width: 0.031inch
  R1 R24 R25: Resistance: 47k
R2 R3 R11-R13 R15-R17 R19 R20 R28 R29 R36 R37 = CMP-2000-00540-1: Manufacturer: UNI_ROYAL; Component Kind: Standard; LCSC: C23186; Library Name: Altium Content Vault; Part Number: 0603WAF5101T5E
R6 R10 R14 = R: 2512 3mohm shunt; Component Kind: Standard; LCSC: C500737; Library Name: Passives.SchLib
R8 = CMP-2000-00540-1: Manufacturer: UNI_ROYAL; Part Number: 0603WAF5101T5E; Component Kind: Standard; LCSC: C22961; Library Name: Altium Content Vault
R21-R23 = R: 0R resistor; Component Kind: Standard; Library Name: Passives.SchLib; Value: 0R
SW1 SW2 = CMP-1696-00003-1: XKB TS-1187A-B-A-B C318884; Component Kind: Standard; LCSC: C318884; Library Name: Altium Content Vault
TP1-TP36 = Test Point: Test point ,1.5mm diameter, no hole; Category: testpoints; Component Kind: Standard; Library Name: DAQ.SchLib
U1 = CMP-04912-000089-1: IC MTR DRV MULTIPHAS 6-60V 48QFN; Output Current: 5mA; Case/Package: VQFN; Component Kind: Standard; Library Name: Altium Content Vault; Max Operating Temperature: 125°C; Min Operating Temperature: -40°C; Mount: Surface Mount; Packaging: Cut Tape; RoHS Compliant: Yes
U2 = CMP-12756-000040-1: IC REG LIN 3.3V 600MA SOT223-3; Accuracy: 2%; Dropout Voltage: 300mV; Max Input Voltage: 6V; Min Current Limit: 800mA; Min Input Voltage: 2V; Number of Outputs: 1; Output Type: Fixed; Polarity: Positive; Quiescent Current: 80uA; Case/Package: SOT-223-3; Component Kind: Standard; Library Name: Altium Content Vault; Max Operating Temperature: 85°C; Max Output Current: 600mA; Min Operating Temperature: -40°C; Mount: Surface Mount; Number of Pins: 4; Number of Regulators: 1; Output Current: 600mA; Output Voltage: 3.3V; Package Quantity: 2500; Packaging: Tape and Reel; Radiation Hardening: No; REACH SVHC: No SVHC; Weight: 0.000282oz
U3 = CMP-0137-00408-1: Tiny 500 mA, High-Speed Power MOSFET Driver, Non Inverting, Extended Temperature, Tape and Reel; Code_IPC: SOT23-5; Component Kind: Standard; DatasheetVersion: revB, Dec-2007; LCSC: C128573; Library Name: Altium Content Vault; PackageDescription: 5-Lead Plastic Small Outline Transistor (OT) [SOT-23]; PackageReference: SOT-23-OT5; PackageVersion: revBB, Aug-2009
U4 = ESP32-S3-WROOM-1-N8R2: WiFi 802.11a/b/g/n, Bluetooth v5.0 Transceiver Module 2.4GHz Antenna Not Included -; MF: Espressif Systems; Availability: In Stock; Check_prices: https://www.snapeda.com/parts/ESP32-S3-WROOM-1-N8R2/Espressif+Systems/view-part/?ref=eda; Component Kind: Standard; Library Name: ESP32-S3-WROOM-1-N8R2.IntLib; MP: ESP32-S3-WROOM-1-N8R2; Package: - Espressif Systems; Price: None; Purchase-URL: https://pricing.snapeda.com/search?q=ESP32-S3-WROOM-1-N8R2&ref=eda; SnapEDA_Link: https://www.snapeda.com/parts/ESP32-S3-WROOM-1-N8R2/Espressif+Systems/view-part/?ref=snap
BRD1 = HCS-301-rolling-code-rx: Component Kind: Standard; Library Name: planinsekLib_sch.SchLib
CN3 = CP2102 USB-A-to-UART adapter connector: Component Kind: Standard; Library Name: planinsekLib_sch.SchLib
J1-J6 = SolderJumperV2: Component Kind: Standard; Library Name: planinsekLib_sch.SchLib
